# Altium schematic sheet 21_RESET_MISC.SchDoc(21_RESET_MISC)
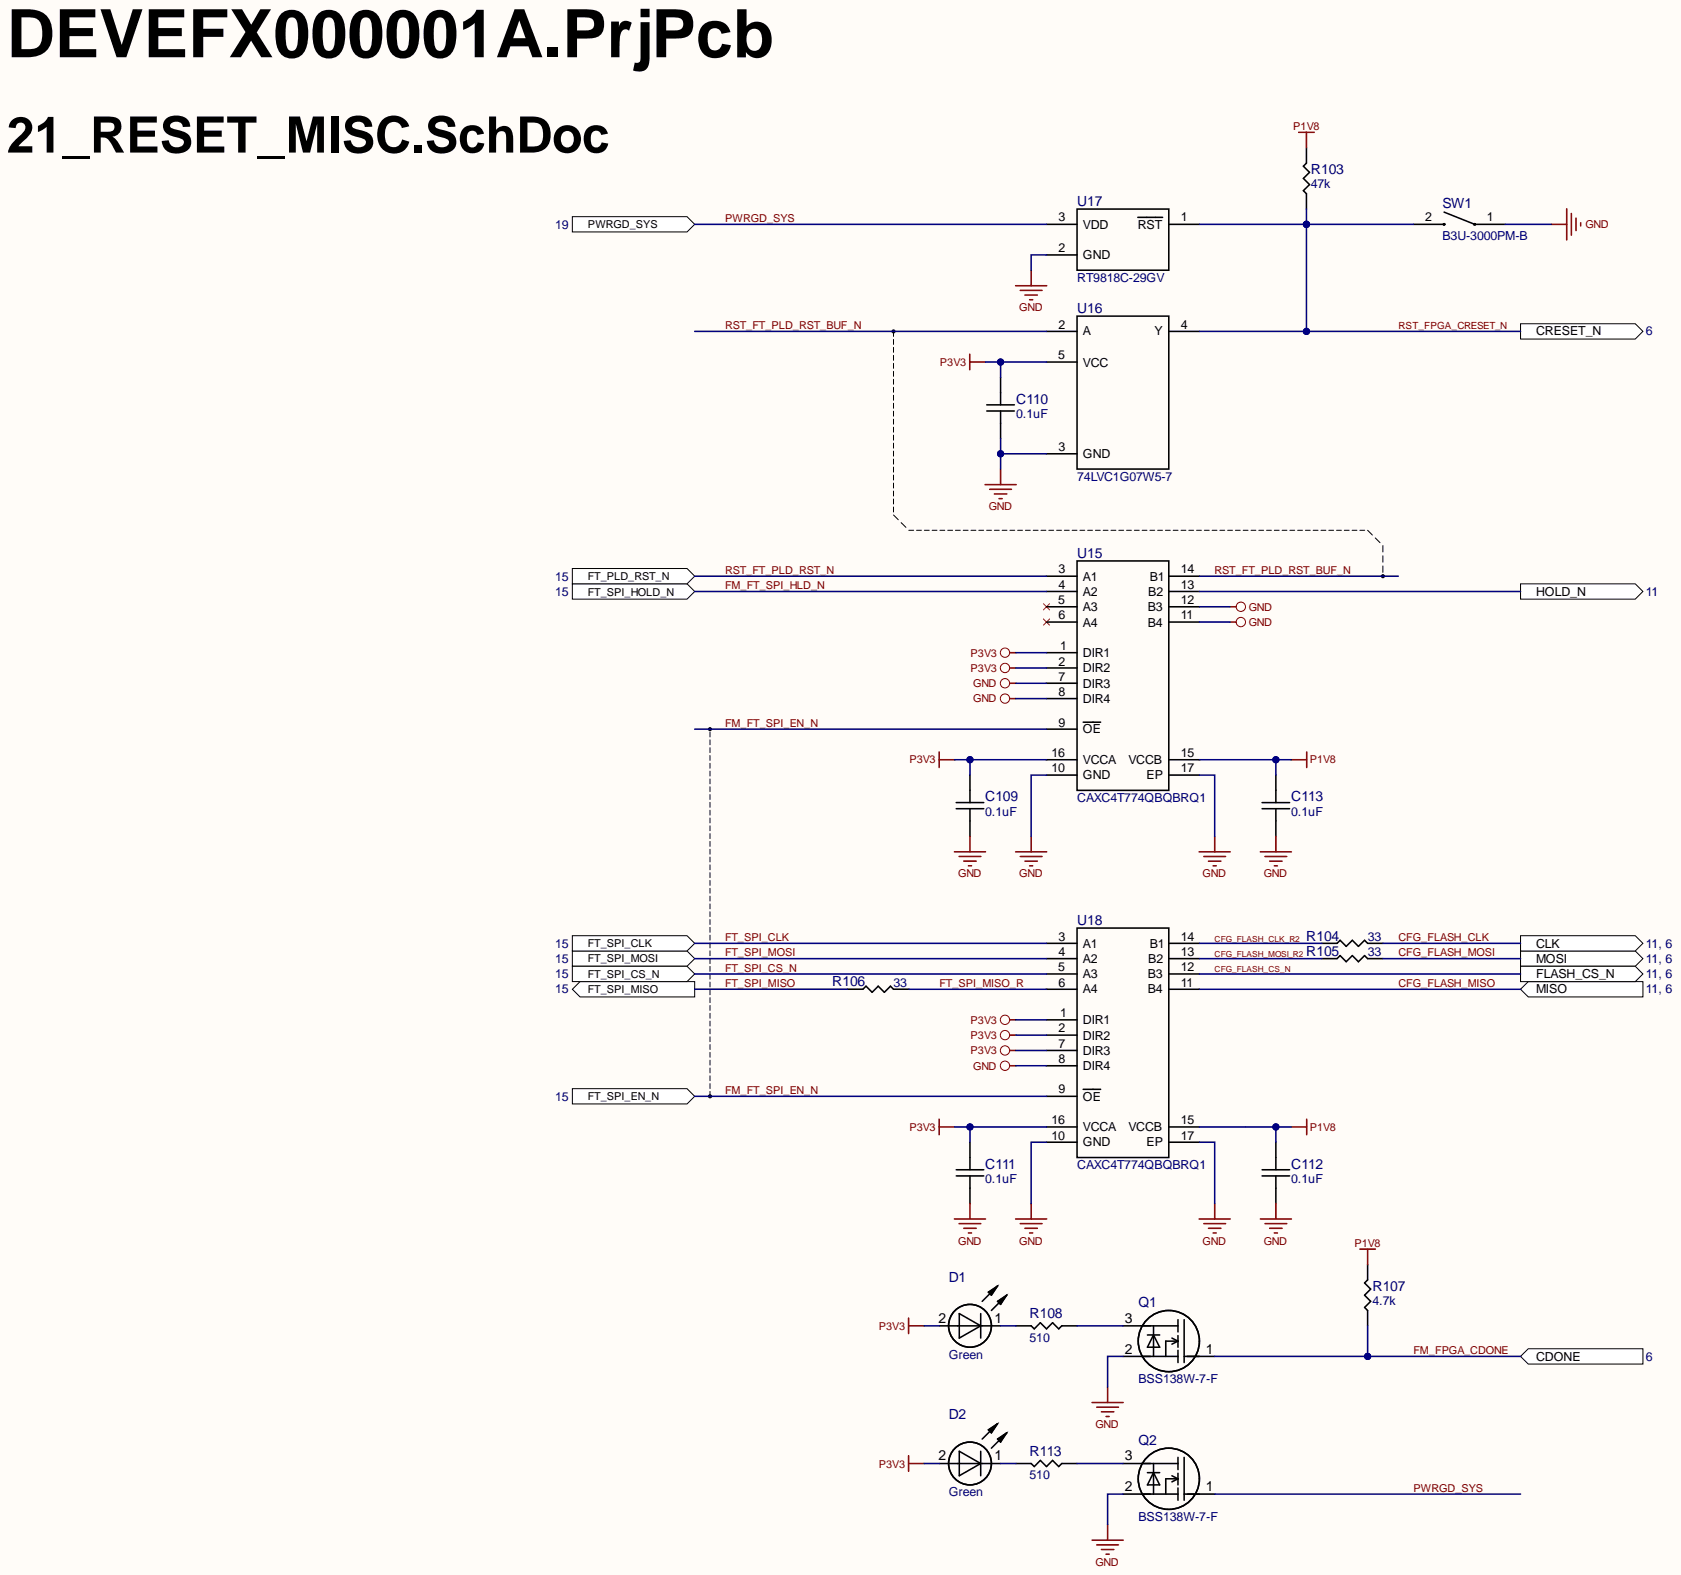
[diagram p0: 21_RESET_MISC.SchDoc]

## Parts
C109  0.1uF  [CAPC1005X06N]
C110  0.1uF  [CAPC1005X06N]
C111  0.1uF  [CAPC1005X06N]
C112  0.1uF  [CAPC1005X06N]
C113  0.1uF  [CAPC1005X06N]
D1  Green  [LED1608]
D2  Green  [LED1608]
Q1  BSS138W-7-F  [SOT65P205X100-3N]
Q2  BSS138W-7-F  [SOT65P205X100-3N]
R103  47k  [RESC1005X03N]
R104  33  [RESC1005X03N]
R105  33  [RESC1005X03N]
R106  33  [RESC1005X03N]
R107  4.7k  [RESC1005X03N]
R108  510  [RESC1005X03N]
R113  510  [RESC1005X03N]
SW1  B3U-3000PM-B  [B3U-3000P(M)-B]
U15  CAXC4T774QBQBRQ1
U16  74LVC1G07W5-7  [SOT95P280X145-5N]
U17  RT9818C-29GV  [SOT95P230X110-3N]
U18  CAXC4T774QBQBRQ1

## Ports
CDONE, CLK, CRESET_N, FLASH_CS_N, FT_PLD_RST_N, FT_SPI_CLK, FT_SPI_CS_N, FT_SPI_EN_N, FT_SPI_HOLD_N, FT_SPI_MISO, FT_SPI_MOSI, HOLD_N, MISO, MOSI, PWRGD_SYS

## Nets
GND: C109.1 C110.1 C111.1 C112.1 C113.1 Q1.2 Q2.2 SW1.1 U15.7(DIR3) U15.8(DIR4) U15.10(GND) U15.11(B4) U15.12(B3) U15.17(EP) U16.3(GND) U17.2 U18.8(DIR4) U18.10(GND) U18.17(EP)
P1V8: C112.2 C113.2 R103.2 R107.2 U15.15(VCCB) U18.15(VCCB)
P3V3: C109.2 C110.2 C111.2 D1.2 D2.2 U15.1(DIR1) U15.2(DIR2) U15.16(VCCA) U16.5(VCC) U18.1(DIR1) U18.2(DIR2) U18.7(DIR3) U18.16(VCCA)
CFG_FLASH_CLK: R104.2 ; port CLK
CFG_FLASH_CLK_R2: R104.1 U18.14(B1)
CFG_FLASH_CS_N: U18.12(B3) ; port FLASH_CS_N
CFG_FLASH_MISO: U18.11(B4) ; port MISO
CFG_FLASH_MOSI: R105.2 ; port MOSI
CFG_FLASH_MOSI_R2: R105.1 U18.13(B2)
FM_FPGA_CDONE: Q1.1 R107.1 ; port CDONE
FM_FT_SPI_EN_N: U15.9(OE) U18.9(OE) ; port FT_SPI_EN_N
FM_FT_SPI_HLD_N: U15.4(A2) ; port FT_SPI_HOLD_N
FT_SPI_CLK: U18.3(A1) ; port FT_SPI_CLK
FT_SPI_CS_N: U18.5(A3) ; port FT_SPI_CS_N
FT_SPI_MISO: R106.1 ; port FT_SPI_MISO
FT_SPI_MISO_R: R106.2 U18.6(A4)
FT_SPI_MOSI: U18.4(A2) ; port FT_SPI_MOSI
HOLD_N: U15.13(B2) ; port HOLD_N
PWRGD_SYS: Q2.1 U17.3 ; port PWRGD_SYS
RST_FPGA_CRESET_N: R103.1 SW1.2 U16.4(Y) U17.1 ; port CRESET_N
RST_FT_PLD_RST_BUF_N: U15.14(B1) U16.2(A)
RST_FT_PLD_RST_N: U15.3(A1) ; port FT_PLD_RST_N
NetD1_1: D1.1 R108.2
NetD2_1: D2.1 R113.2
NetQ1_3: Q1.3 R108.1
NetQ2_3: Q2.3 R113.1

Not connected: U15 5(A3) 6(A4)

## Library data of the parts
C109-C113 = GRM155R71E104KE14J: CAP CER 0.1UF 25V X7R 0402; Manufacturer 1: Murata Electronics; Database Table Name: C$; Tol: 10%; Component Kind: Standard; Library Name: Database.DbLib; Manufacturer Part Number 1: GRM155R71E104KE14J; Part Number: GRM155R71E104KE14J; Supplier 1: Digi-Key; Supplier Part Number 1: 490-14603-1-ND; TempC: X7R; Value: 0.1uF; Voltage: 25V
D1 D2 = APT1608SGC: LED GREEN CLEAR CHIP SMD; Manufacturer 1: Kingbright; Database Table Name: Discrete Semiconductors$; Component Kind: Standard; Library Name: Database.DbLib; Manufacturer Part Number 1: APT1608SGC; Part Number: APT1608SGC; Supplier 1: Digi-Key; Supplier Part Number 1: 754-1121-1-ND
Q1 Q2 = BSS138W-7-F: MOSFET N-CH 50V 200MA SOT323; Manufacturer 1: Diodes Incorporated; Database Table Name: Discrete Semiconductors$; Component Kind: Standard; Library Name: Database.DbLib; Manufacturer Part Number 1: BSS138W-7-F; Part Number: BSS138W-7-F; Supplier 1: Digi-Key; Supplier Part Number 1: BSS138W-FDICT-ND
R103 = RC0402FR-0747KL: RES SMD 47K OHM 1% 1/16W 0402; Manufacturer 1: Yageo; Database Table Name: R$; Component Kind: Standard; Library Name: Database.DbLib; Manufacturer Part Number 1: RC0402FR-0747KL; Part Number: RC0402FR-0747KL; Supplier 1: Digi-Key; Supplier Part Number 1: 311-47.0KLRCT-ND; Tol: 1%; Value: 47k
R104-R106 = RC0402FR-0733RL: RES SMD 33 OHM 1% 1/16W 0402; Manufacturer 1: Yageo; Database Table Name: R$; Component Kind: Standard; Library Name: Database.DbLib; Manufacturer Part Number 1: RC0402FR-0733RL; Part Number: RC0402FR-0733RL; Supplier 1: Digi-Key; Supplier Part Number 1: 311-33.0LRCT-ND; Tol: 1%; Value: 33
R107 = RC0402FR-074K7L: RES SMD 4.7K OHM 1% 1/16W 0402; Manufacturer 1: Yageo; Database Table Name: R$; Component Kind: Standard; Library Name: Database.DbLib; Manufacturer Part Number 1: RC0402FR-074K7L; Part Number: RC0402FR-074K7L; Supplier 1: Digi-Key; Supplier Part Number 1: 311-4.7KLRCT-ND; Tol: 1%; Value: 4.7k
R108 R113 = RC0402JR-07510RL: RES 510 OHM 5% 1/16W 0402; Manufacturer 1: Yageo; Database Table Name: R$; Tol: 1%; Component Kind: Standard; Library Name: Database.DbLib; Manufacturer Part Number 1: RC0402JR-07510RL; Part Number: RC0402JR-07510RL; Supplier 1: Digi-Key; Supplier Part Number 1: 311-510JRCT-ND; Value: 510
SW1 = B3U-3000PM-B: SWITCH TACTILE SPST-NO 0.05A 12V; Manufacturer 1: Omron Electronics Inc-EMC Div; Database Table Name: Mech$; Component Kind: Standard; Library Name: Database.DbLib; Manufacturer Part Number 1: B3U-3000PM-B; Part Number: B3U-3000PM-B; Supplier 1: Digi-Key; Supplier Part Number 1: Z8276CT-ND
U15 U18 = CAXC4T774QBQBRQ1: AUTOMOTIVE 4-BIT 2-SUPPLY BUS TR; Manufacturer 1: Texas Instruments; Database Table Name: ICs$; Component Kind: Standard; Library Name: Database.DbLib; Manufacturer Part Number 1: CAXC4T774QBQBRQ1; Part Number: CAXC4T774QBQBRQ1; Supplier 1: Digi-Key; Supplier Part Number 1: 296-CAXC4T774QBQBRQ1CT-ND
U16 = 74LVC1G07W5-7: IC BUFFER NON-INVERT 5.5V SOT25; Manufacturer 1: Diodes Incorporated; Database Table Name: ICs$; Component Kind: Standard; Library Name: Database.DbLib; Manufacturer Part Number 1: 74LVC1G07W5-7; Part Number: 74LVC1G07W5-7; Supplier 1: Digi-Key; Supplier Part Number 1: 74LVC1G07W5-7DICT-ND
U17 = RT9818C-29GV: IC SUPERVISOR 1 CHANNEL SOT23-3; Manufacturer 1: Richtek USA Inc.; Database Table Name: ICs$; Component Kind: Standard; Library Name: Database.DbLib; Manufacturer Part Number 1: RT9818C-29GV; Part Number: RT9818C-29GV; Supplier 1: Digi-Key; Supplier Part Number 1: 1028-1020-1-ND
